# Altium schematic sheet IOpic2.SchDoc
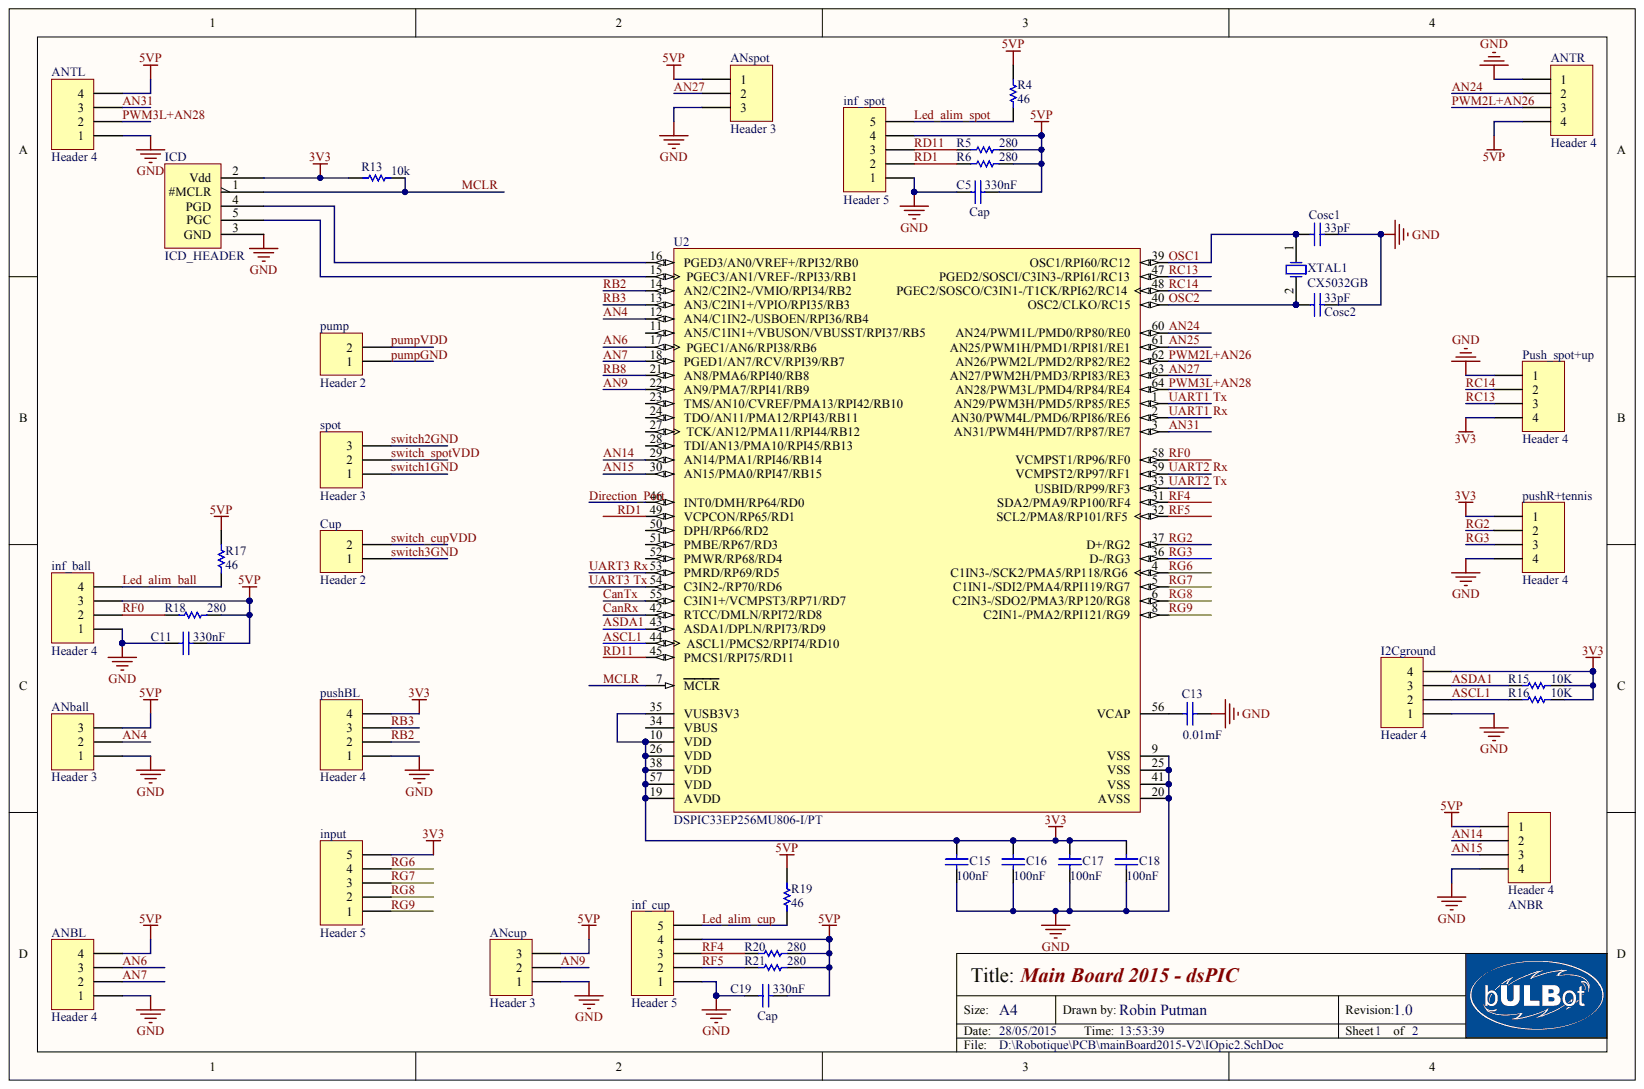
[diagram p0: IOpic2.SchDoc]

## Parts
ANball  Header 3
ANBL  Header 4
ANBR  Header 4
ANcup  Header 3
ANspot  Header 3
ANTL  Header 4
ANTR  Header 4
C5  Cap  value 330nF
C11  value 330nF  (hidden comment: Cap)
C13  value 0.01mF  (hidden comment: Cap)
C15  value 100nF  (hidden comment: Cap)
C16  value 100nF  (hidden comment: Cap)
C17  value 100nF  (hidden comment: Cap)
C18  value 100nF  (hidden comment: Cap)
C19  Cap  value 330nF
Cosc1  value 33pF  (hidden comment: Cap)
Cosc2  value 33pF  (hidden comment: Cap)
Cup  Header 2
I2Cground  Header 4
ICD  ICD_HEADER
inf_ball  Header 4
inf_cup  Header 5
inf_spot  Header 5
input  Header 5
pump  Header 2
Push_spot+up  Header 4
pushBL  Header 4
pushR+tennis  Header 4
R4  value 46  (hidden comment: Res1)
R5  value 280  (hidden comment: Res1)
R6  value 280  (hidden comment: Res1)
R13  value 10k  (hidden comment: Res1)
R15  value 10K  (hidden comment: Res1)
R16  value 10K  (hidden comment: Res1)
R17  value 46  (hidden comment: Res1)
R18  value 280  (hidden comment: Res1)
R19  value 46  (hidden comment: Res1)
R20  value 280  (hidden comment: Res1)
R21  value 280  (hidden comment: Res1)
spot  Header 3
U2  DSPIC33EP256MU806-I/PT
XTAL1  CX5032GB

## Nets
3V3: C15.1 C16.1 C17.1 C18.1 I2Cground.4 ICD.2(Vdd) input.5 Push_spot+up.4 pushBL.4 pushR+tennis.1 R13.1 R15.1 R16.1 U2.10(VDD) U2.19(AVDD) U2.26(VDD) U2.35(VUSB3V3) U2.38(VDD) U2.57(VDD)
5VP: ANball.3 ANBL.4 ANBR.1 ANcup.3 ANspot.1 ANTL.4 ANTR.4 C5.1 C11.1 C19.1 inf_ball.3 inf_cup.4 inf_spot.4 R4.2 R5.2 R6.2 R17.2 R18.2 R19.2 R20.2 R21.2
GND: ANball.1 ANBL.1 ANBR.4 ANcup.1 ANspot.3 ANTL.1 ANTR.1 C5.2 C11.2 C13.2 C15.2 C16.2 C17.2 C18.2 C19.2 Cosc1.1 Cosc2.1 I2Cground.1 ICD.3(GND) inf_ball.1 inf_cup.1 inf_spot.1 Push_spot+up.1 pushBL.1 pushR+tennis.4 U2.9(VSS) U2.20(AVSS) U2.25(VSS) U2.41(VSS)
AN4: ANball.2 U2.12(AN4/C1IN2-/USBOEN/RPI36/RB4)
AN6: ANBL.3 U2.17(PGEC1/AN6/RPI38/RB6)
AN7: ANBL.2 U2.18(PGED1/AN7/RCV/RPI39/RB7)
AN9: ANcup.2 U2.22(AN9/PMA7/RPI41/RB9)
AN14: ANBR.2 U2.29(AN14/PMA1/RPI46/RB14)
AN15: ANBR.3 U2.30(AN15/PMA0/RPI47/RB15)
AN24: ANTR.2 U2.60(AN24/PWM1L/PMD0/RP80/RE0)
AN25: U2.61(AN25/PWM1H/PMD1/RPI81/RE1)
AN27: ANspot.2 U2.63(AN27/PWM2H/PMD3/RPI83/RE3)
AN31: ANTL.3 U2.3(AN31/PWM4H/PMD7/RP87/RE7)
ASCL1: I2Cground.2 R16.2 U2.44(ASCL1/PMCS2/RPI74/RD10)
ASDA1: I2Cground.3 R15.2 U2.43(ASDA1/DPLN/RPI73/RD9)
CANRX: U2.42(RTCC/DMLN/RPI72/RD8)
CANTX: U2.55(C3IN1+/VCMPST3/RP71/RD7)
DIRECTION_PORT: U2.46(INT0/DMH/RP64/RD0)
LED_ALIM_BALL: inf_ball.4 R17.1
LED_ALIM_CUP: inf_cup.5 R19.1
LED_ALIM_SPOT: inf_spot.5 R4.1
MCLR: ICD.1(#MCLR) R13.2 U2.7(MCLR)
OSC1: Cosc1.2 U2.39(OSC1/RPI60/RC12) XTAL1.1
OSC2: Cosc2.2 U2.40(OSC2/CLKO/RC15) XTAL1.2
PUMPGND: pump.1
PUMPVDD: pump.2
PWM2L+AN26: ANTR.3 U2.62(AN26/PWM2L/PMD2/RP82/RE2)
PWM3L+AN28: ANTL.2 U2.64(AN28/PWM3L/PMD4/RP84/RE4)
RB2: pushBL.2 U2.14(AN2/C2IN2-/VMIO/RPI34/RB2)
RB3: pushBL.3 U2.13(AN3/C2IN1+/VPIO/RPI35/RB3)
RB8: U2.21(AN8/PMA6/RPI40/RB8)
RC13: Push_spot+up.3 U2.47(PGED2/SOSCI/C3IN3-/RPI61/RC13)
RC14: Push_spot+up.2 U2.48(PGEC2/SOSCO/C3IN1-/T1CK/RPI62/RC14)
RD1: inf_spot.2 R6.1 U2.49(VCPCON/RP65/RD1)
RD11: inf_spot.3 R5.1 U2.45(PMCS1/RPI75/RD11)
RF0: inf_ball.2 R18.1 U2.58(VCMPST1/RP96/RF0)
RF4: inf_cup.3 R20.1 U2.31(SDA2/PMA9/RP100/RF4)
RF5: inf_cup.2 R21.1 U2.32(SCL2/PMA8/RP101/RF5)
RG2: pushR+tennis.2 U2.37(D+/RG2)
RG3: pushR+tennis.3 U2.36(D-/RG3)
RG6: input.4 U2.4(C1IN3-/SCK2/PMA5/RP118/RG6)
RG7: input.3 U2.5(C1IN1-/SDI2/PMA4/RPI119/RG7)
RG8: input.2 U2.6(C2IN3-/SDO2/PMA3/RP120/RG8)
RG9: input.1 U2.8(C2IN1-/PMA2/RPI121/RG9)
SWITCH1GND: spot.1
SWITCH2GND: spot.3
SWITCH3GND: Cup.1
SWITCH_CUPVDD: Cup.2
SWITCH_SPOTVDD: spot.2
UART1 RX: U2.2(AN30/PWM4L/PMD6/RPI86/RE6)
UART1 TX: U2.1(AN29/PWM3H/PMD5/RP85/RE5)
UART2 RX: U2.59(VCMPST2/RP97/RF1)
UART2 TX: U2.33(USBID/RP99/RF3)
UART3 RX: U2.53(PMRD/RP69/RD5)
UART3 TX: U2.54(C3IN2-/RP70/RD6)
NetC13_1: C13.1 U2.56(VCAP)
NetICD_4: ICD.4(PGD) U2.16(PGED3/AN0/VREF+/RPI32/RB0)
NetICD_5: ICD.5(PGC) U2.15(PGEC3/AN1/VREF-/RPI33/RB1)

Not connected: U2 11(AN5/C1IN1+/VBUSON/VBUSST/RPI37/RB5) 23(TMS/AN10/CVREF/PMA13/RPI42/RB10) 24(TDO/AN11/PMA12/RPI43/RB11) 27(TCK/AN12/PMA11/RPI44/RB12) 28(TDI/AN13/PMA10/RPI45/RB13) 34(VBUS) 50(DPH/RP66/RD2) 51(PMBE/RP67/RD3) 52(PMWR/RP68/RD4)

## Library data of the parts
U2 = (no symbol name): Manufacturer: Microchip; DatasheetVersion: Rev. G; PackageVersion: C04-085B; PackageReference: TQFP-PT64; PackageDescription: 64-Lead Plastic Thin Quad FlatPack, 10 x 10 mm Body, 0.5 mm Pitch; Supplier 1: Digi-Key; Supplier Part Number 1: DSPIC33EP256MU806-I/PT-ND; Supplier 2: Farnell; Supplier Part Number 2: 1971850; Supplier 3: Farnell; Supplier Part Number 3: 2119666; Supplier 4: Newark; Supplier Part Number 4: 65R5390; Supplier 5: Mouser; Supplier Part Number 5: 579-PIC33256MU806IPT; Mounting Technology: Surface Mount; PartNumber: DSPIC33EP256MU806-I/PT; ComponentLink1Description: Manufacturer URL; ComponentLink1URL: http://www.microchip.com; ComponentLink2Description: Datasheet; ComponentLink2URL: http://ww1.microchip.com/downloads/en/DeviceDoc/70616g.pdf; ComponentLink3Description: Package Specification; ComponentLink3URL: http://www.microchip.com/stellent/groups/techpub_sg/documents/packagingspec/en012702.pdf; ComponentLink4Description: Product URL; ComponentLink4URL: http://www.microchip.com/dsPIC33EP256MU806
XTAL1 = (no symbol name): Note: PCB layout complies with manufacturer's recommendation.; Published: 17-Jul-2002; DatasheetDocument: 26-Mar-1998; PackageDocument: 26-Mar-1998; PackageReference: CX-1-SM; ComponentLink1Description: Manufacturer Link; ComponentLink1URL: http://www.cmac.com/mt/; ComponentLink2Description: Datasheet_LF; ComponentLink2URL: Http://www.cmac.com/mt/databook/crystals/smd/cx-1-smd-lf.pdf; ComponentLink3Description: Pinout Specification; ComponentLink3URL: Datasheet_HF|http://www.cmac.com/mt/databook/crystals/smd/cx-1-smd-hf.pdf; Publisher: Altium Limited
ANball ANBL ANBR ANcup ANspot ANTL ANTR C5 C11 C13 C15-C19 Cosc1 Cosc2 Cup I2Cground ICD inf_ball inf_cup inf_spot input pump Push_spot+up pushBL pushR+tennis R4-R6 R13 R15-R21 spot = (no symbol name)
  ANball ANBL ANBR ANcup ANspot ANTL ANTR Cup I2Cground inf_ball inf_cup inf_spot input pump Push_spot+up pushBL pushR+tennis spot: LatestRevisionDate: 17-Jul-2002; LatestRevisionNote: Re-released for DXP Platform.
  C5 C11 C13 C15-C19: Published: 8-Jun-2000; LatestRevisionDate: 17-Jul-2002; LatestRevisionNote: Re-released for DXP Platform.; PackageReference: RAD-0.3; PackageDescription: Radial Cap, Thru-Hole; 2 Leads; 0.3 in Pin Spacing
  Cosc1 Cosc2: Published: 8-Jun-2000; LatestRevisionDate: 17-Jul-2002; LatestRevisionNote: Re-released for DXP Platform.; PackageReference: RAD-0.3
  R4-R6 R13 R15-R21: Published: 8-Jun-2000; LatestRevisionDate: 17-Jul-2002; LatestRevisionNote: Re-released for DXP Platform.; PackageReference: AXIAL-0.3; PackageDescription: Axial Device, Thru-Hole; 2 Leads; 0.3 in Pin Spacing
  ANball ANBL ANBR ANcup ANspot ANTL ANTR C5 C11 C13 C15-C19 Cosc1 Cosc2 Cup I2Cground inf_ball inf_cup inf_spot input pump Push_spot+up pushBL pushR+tennis R4-R6 R13 R15-R21 spot: Publisher: Altium Limited
